# Altium schematic sheet DEVICE.SchDoc(DEVICE)
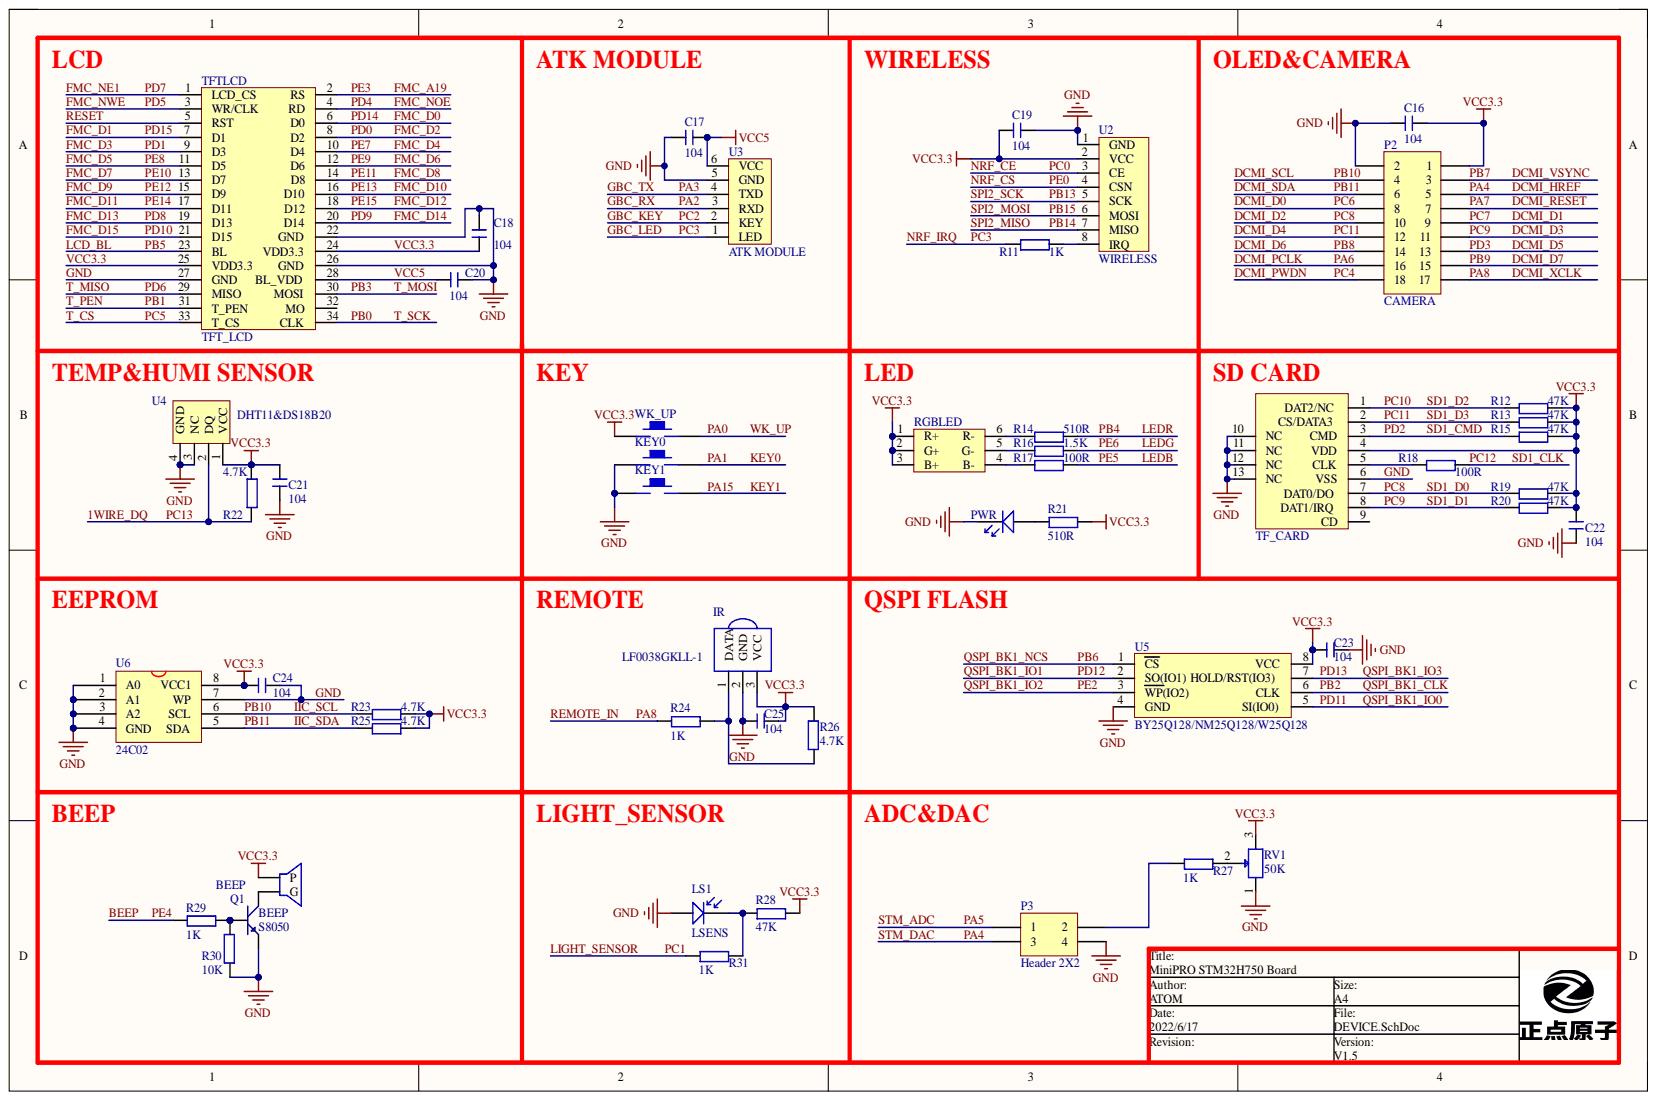
[diagram p0: DEVICE.SchDoc]

## Parts
BEEP  BEEP  [BELL-5]
C16  104  [C 0402]
C17  104  [C 0402]
C18  104  [C 0402]
C19  104  [C 0402]
C20  104  [C 0402]
C21  104  [C 0402]
C22  104  [C 0402]
C23  104  [C 0402]
C24  104  [C 0402]
C25  104  [C 0402]
IR  LF0038GKLL-1  [LF0038GKLL-1]
KEY0  KEY_M  [KEY_SOP324225]  (value not drawn)
KEY1  KEY_M  [KEY_SOP324225]  (value not drawn)
LED1  PWR  [SMDLED-0603]
LS1  LSENS  [SMDLED-0805]
P2  CAMERA  [HDR2X9]
P3  Header 2X2  [HDR2X2]
Q1  S8050  [SOT-23R]
R11  1K  [0402]
R12  47K  [0402]
R13  47K  [0402]
R14  510R  [0402]
R15  47K  [0402]
R16  1.5K  [0402]
R17  100R  [0402]
R18  100R  [0402]
R19  47K  [0402]
R20  47K  [0402]
R21  510R  [0402]
R22  4.7K  [0402]
R23  4.7K  [0402]
R24  1K  [0402]
R25  4.7K  [0402]
R26  4.7K  [0402]
R27  1K  [0402]
R28  47K  [0402]
R29  1K  [0402]
R30  10K  [0402]
R31  1K  [0402]
RGBLED  RGBLED  [PLCC-5050]
RV1  50K  [RV]
TF_CARD  SD-MICRO-TF  [SD-MICRO-TF]  (value not drawn)
TFTLCD  TFT_LCD  [db2*17-4.3]
U2  WIRELESS  [NRF24L01]
U3  ATK MODULE  [HEAD6]
U4  DHT11&DS18B20  [DHT11]
U5  BY25Q128/NM25Q128/W25Q128  [SOP8W_L]
U6  24C02  [SOP-8]
WK_UP  KEY_M  [KEY_SOP324225]  (value not drawn)

## Nets
1WIRE_DQ: R22.1 U4.2(DQ)
BEEP: R29.1
DCMI_D0: P2.8
DCMI_D1: P2.9
DCMI_D2: P2.10 R19.1 TF_CARD.7(DAT0/DO)
DCMI_D3: P2.11 R20.1 TF_CARD.8(DAT1/IRQ)
DCMI_D4: P2.12 R13.1 TF_CARD.2(CS/DATA3)
DCMI_D5: P2.13
DCMI_D6: P2.14
DCMI_D7: P2.15
DCMI_HREF: P2.5 P3.3
DCMI_PCLK: P2.16
DCMI_PWDN: P2.18
DCMI_RESET: P2.7
DCMI_SCL: P2.4 R23.1 U6.6(SCL)
DCMI_SDA: P2.6 R25.1 U6.5(SDA)
DCMI_VSYNC: P2.3
DCMI_XCLK: P2.17 R24.1
FMC_A19: TFTLCD.2(RS)
FMC_D0: TFTLCD.6(D0)
FMC_D1: TFTLCD.7(D1)
FMC_D2: TFTLCD.8(D2)
FMC_D3: TFTLCD.9(D3)
FMC_D4: TFTLCD.10(D4)
FMC_D5: TFTLCD.11(D5)
FMC_D6: TFTLCD.12(D6)
FMC_D7: TFTLCD.13(D7)
FMC_D8: TFTLCD.14(D8)
FMC_D9: TFTLCD.15(D9)
FMC_D10: TFTLCD.16(D10)
FMC_D11: TFTLCD.17(D11)
FMC_D12: TFTLCD.18(D12)
FMC_D13: TFTLCD.19(D13)
FMC_D14: TFTLCD.20(D14)
FMC_D15: TFTLCD.21(D15)
FMC_NE1: TFTLCD.1(LCD_CS)
FMC_NOE: TFTLCD.4(RD)
FMC_NWE: TFTLCD.3(WR/CLK)
GBC_KEY: U3.2(KEY)
GBC_LED: R11.1 U3.1(LED)
GBC_RX: U3.3(RXD)
GBC_TX: U3.4(TXD)
GND: C16.1 C17.2 C18.1 C19.1 C20.1 C21.1 C22.2 C23.2 C24.1 C25.2 IR.2 KEY0.1 KEY1.1 LED1.2 LS1.1 P2.2 P3.4 Q1.1 R30.2 RV1.1 TF_CARD.6(VSS) TF_CARD.10(NC) TF_CARD.11(NC) TF_CARD.12(NC) TF_CARD.13(NC) TFTLCD.22(GND) TFTLCD.26(GND) TFTLCD.27(GND) U2.1(GND) U3.5(GND) U4.3(NC) U4.4(GND) U5.4(GND) U6.1(A0) U6.2(A1) U6.3(A2) U6.4(GND) U6.7(WP)
KEY0: KEY0.2
KEY1: KEY1.2
LCD_BL: TFTLCD.23(BL)
LEDB: R17.2
LEDG: R16.2
LEDR: R14.2
LIGHT_SENSOR: R31.1
NRF_CE: U2.3(CE)
NRF_CS: U2.4(CSN)
PA0: WK_UP.2
PA5: P3.1
PB0: TFTLCD.34(CLK)
PB1: TFTLCD.31(T_PEN)
PB2: U5.6(CLK)
PB3: TFTLCD.30(MOSI)
PB6: U5.1(CS)
PB13: U2.5(SCK)
PB14: U2.7(MISO)
PB15: U2.6(MOSI)
PC5: TFTLCD.33(T_CS)
PC10: R12.1 TF_CARD.1(DAT2/NC)
PC12: R18.2
PD2: R15.1 TF_CARD.3(CMD)
PD6: TFTLCD.29(MISO)
PD11: U5.5(SI(IO0))
PD12: U5.2(SO(IO1))
PD13: U5.7(HOLD/RST(IO3))
PE2: U5.3(WP(IO2))
RESET: TFTLCD.5(RST)
VCC3.3: BEEP.1 C16.2 C18.2 C19.2 C21.2 C22.1 C23.1 C24.2 C25.1 IR.3 P2.1 R12.2 R13.2 R15.2 R19.2 R20.2 R21.2 R22.2 R23.2 R25.2 R26.1 R28.2 RGBLED.1(R+) RGBLED.2(G+) RGBLED.3(B+) RV1.3 TF_CARD.4(VDD) TFTLCD.24(VDD3.3) TFTLCD.25(VDD3.3) U2.2(VCC) U4.1(VCC) U5.8(VCC) U6.8(VCC1) WK_UP.1
VCC5: C17.1 C20.2 TFTLCD.28(BL_VDD) U3.6(VCC)
NetBEEP_2: BEEP.2 Q1.3
NetIR_1: IR.1 R24.2 R26.2
NetLED1_1: LED1.1 R21.1
NetLS1_2: LS1.2 R28.1 R31.2
NetP3_2: P3.2 R27.1
NetQ1_2: Q1.2 R29.2 R30.1
NetR11_2: R11.2 U2.8(IRQ)
NetR14_1: R14.1 RGBLED.6(R-)
NetR16_1: R16.1 RGBLED.5(G-)
NetR17_1: R17.1 RGBLED.4(B-)
NetR18_1: R18.1 TF_CARD.5(CLK)
NetR27_2: R27.2 RV1.2

Not connected: TF_CARD 9(CD); TFTLCD 32(MO)

## Library data of the parts
C16-C25 = C: 无极性贴片电容; 版权归属: 源创客; BOM名称: 无极性贴片电容; Component Kind: Standard; Library Name: 电阻-电容-电感.SchLib; 学习资源: www.ooocke.com
IR = LF0038GKLL-1: 红外接收管SMD; Component Kind: Standard; Library Name: ALIENTEK_COMPONENT.IntLib
LED1 = LED: Component Kind: Standard; Library Name: ALIENTEK_COMPONENT.IntLib
LS1 = LSENS: LIGHT SENS; Component Kind: Standard; Library Name: ALIENTEK_COMPONENT.IntLib
P2 = Header 9X2: Header, 9-Pin, Dual row; Component Kind: Standard; LatestRevisionDate: 17-Jul-2002; LatestRevisionNote: Re-released for DXP Platform.; Library Name: Miscellaneous Connectors.IntLib; Publisher: Altium Limited
P3 = Header 2X2: Header, 2-Pin, Dual row; Component Kind: Standard; LatestRevisionDate: 17-Jul-2002; LatestRevisionNote: Re-released for DXP Platform.; Library Name: Miscellaneous Connectors.IntLib; Signal Integrity: Connector; Publisher: Altium Limited
Q1 = NPN: 8050/BCW846/BCW847; Component Kind: Standard; Library Name: MYCOMLIB.IntLib
R11-R31 = R: Component Kind: Standard
  R11 R22-R31: Library Name: MYCOMLIB.IntLib
  R12-R21: Library Name: ALIENTEK_COMPONENT.IntLib
RGBLED = RGBLED-5050: Component Kind: Standard; Library Name: ALIENTEK_COMPONENT.IntLib
RV1 = RV: Component Kind: Standard; Library Name: ALIENTEK_COMPONENT.IntLib
TF_CARD = SD-MICRO-TF: SD,MICRO,TF; Component Kind: Standard; Library Name: ALIENTEK_COMPONENT.IntLib
U2 = NRF24L01: Component Kind: Standard; Library Name: MYCOMLIB.IntLib
U3 = ATK-HC05: Component Kind: Standard; Description: ATK-HC05; Library Name: ALIENTEK_COMPONENT.IntLib
U4 = DHT11: 数字温湿度传感器; Component Kind: Standard; Library Name: ALIENTEK_COMPONENT.IntLib
U5 = W25Q256: 外置flash; Component Kind: Standard; Library Name: Lycreturn.IntLib
U6 = 24C256: Component Kind: Standard; Library Name: MYCOMLIB.IntLib
BEEP = BEEP: Component Kind: Standard; Library Name: ALIENTEK_COMPONENT.IntLib
KEY0 KEY1 WK_UP = KEY_M: Component Kind: Standard; Library Name: ALIENTEK_COMPONENT.IntLib
TFTLCD = TFT_LCD: Component Kind: Standard; Library Name: ALIENTEK_COMPONENT.IntLib
